# Altium schematic sheet HEATER.SchDoc(HEATER)
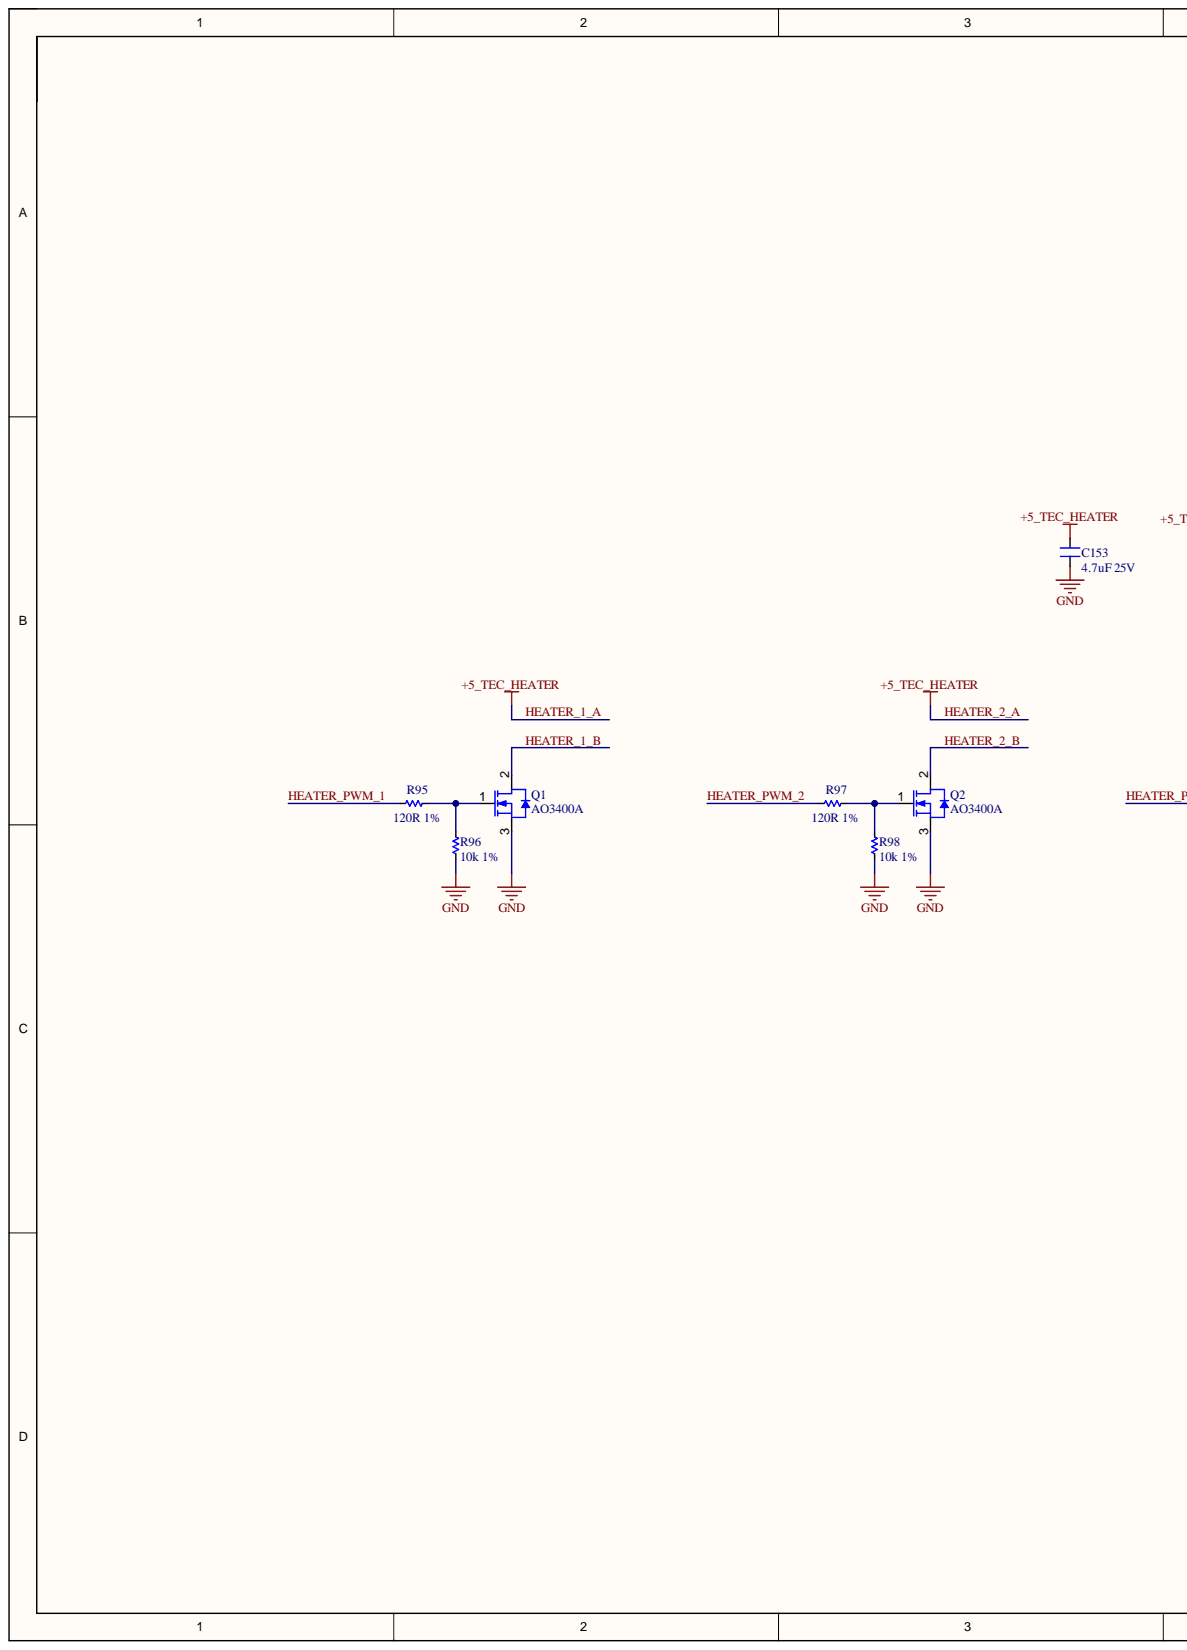
[diagram p0: HEATER.SchDoc, left]
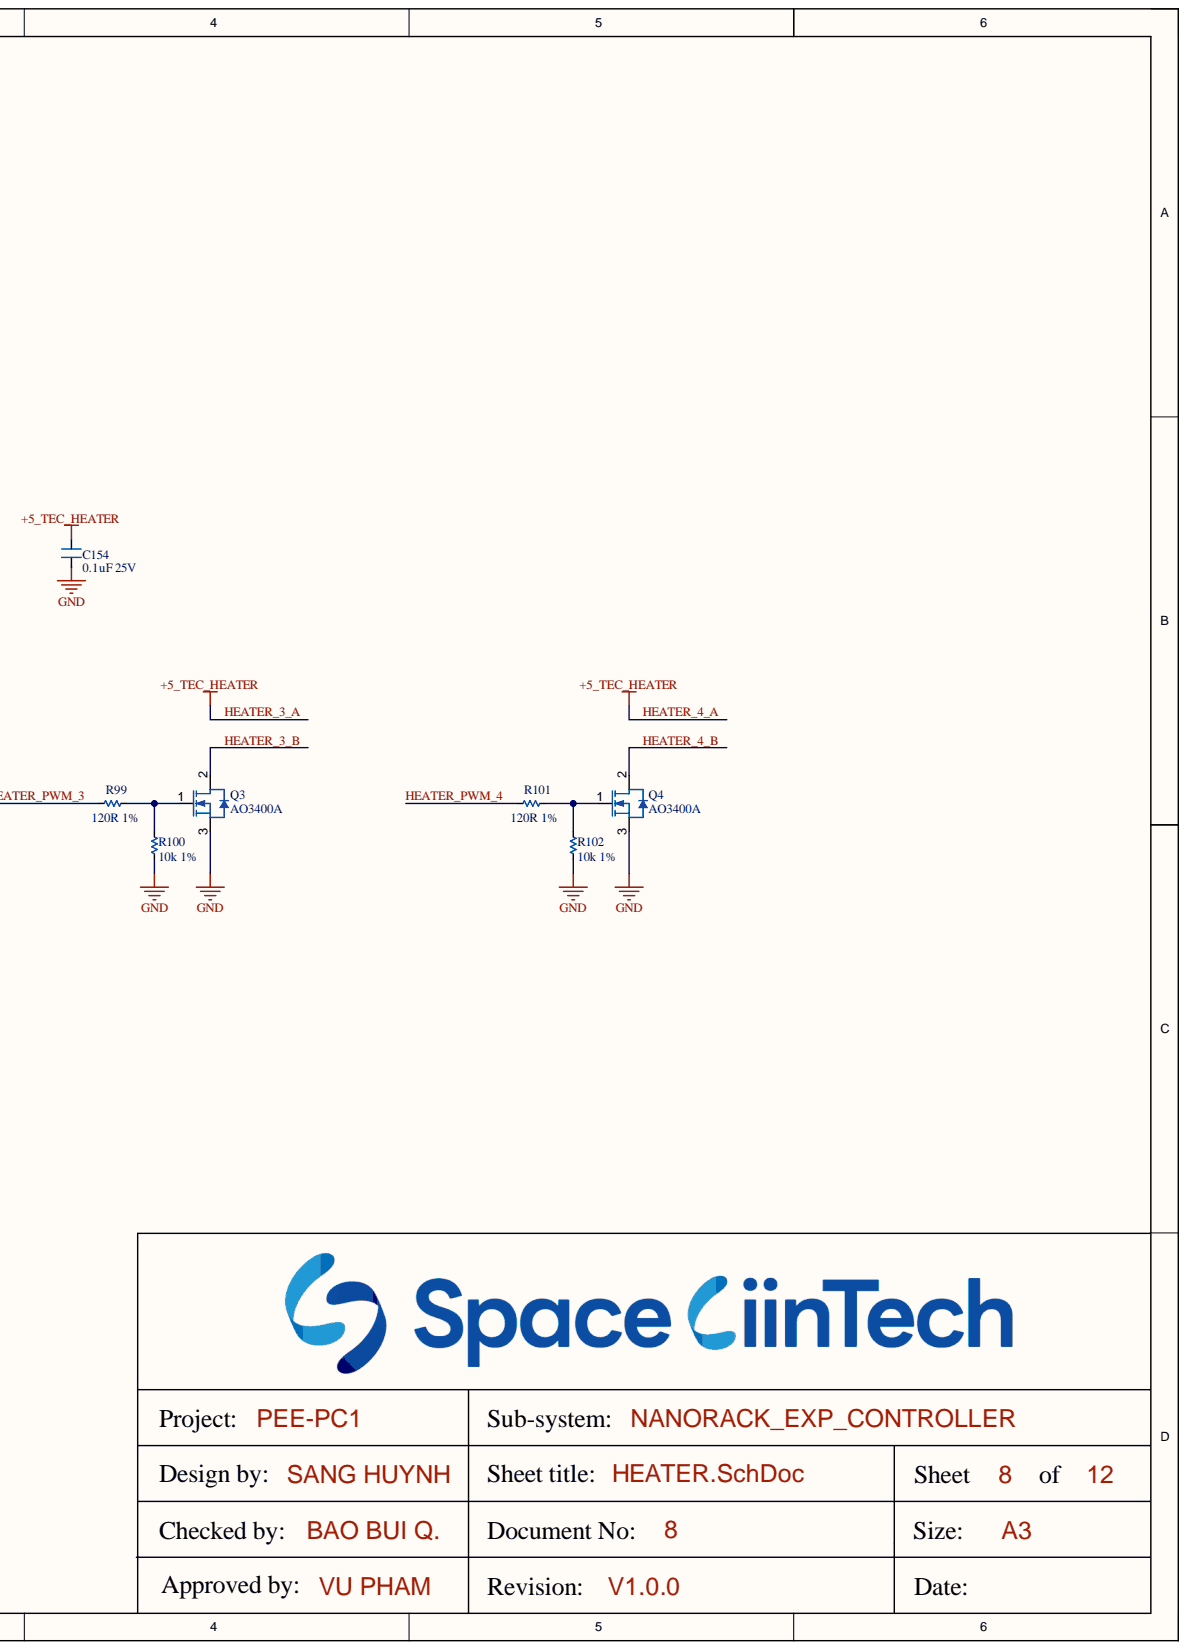
[diagram p1: HEATER.SchDoc, right]

## Parts
C153  4.7uF 25V  [CN-SMD-0603]
C154  0.1uF 25V  [CN-SMD-0603]
Q1  AO3400A  [SOT23-13_2]
Q2  AO3400A  [SOT23-13_2]
Q3  AO3400A  [SOT23-13_2]
Q4  AO3400A  [SOT23-13_2]
R95  120R 1%  [RES-SMD-0603]
R96  10k 1%  [RES-SMD-0603]
R97  120R 1%  [RES-SMD-0603]
R98  10k 1%  [RES-SMD-0603]
R99  120R 1%  [RES-SMD-0603]
R100  10k 1%  [RES-SMD-0603]
R101  120R 1%  [RES-SMD-0603]
R102  10k 1%  [RES-SMD-0603]

## Nets
GND: C153.1 C154.1 Q1.3 Q2.3 Q3.3 Q4.3 R96.1 R98.1 R100.1 R102.1
HEATER_1_A: C153.2 C154.2
HEATER_1_B: Q1.2
HEATER_2_B: Q2.2
HEATER_3_B: Q3.2
HEATER_4_B: Q4.2
HEATER_PWM_1: R95.1
HEATER_PWM_2: R97.1
HEATER_PWM_3: R99.1
HEATER_PWM_4: R101.1
NetQ1_1: Q1.1 R95.2 R96.2
NetQ2_1: Q2.1 R97.2 R98.2
NetQ3_1: Q3.1 R99.2 R100.2
NetQ4_1: Q4.1 R101.2 R102.2

## Library data of the parts
C153 C154 = Capacitors: Value: 33pF; Component Kind: Standard; Library Name: Sang_library.SchLib
Q1-Q4 = AO3400A: MOSFET N-CH 30V 5.7A SOT23-3L; Component Kind: Standard; Library Name: Sang_library.SchLib
R95-R102 = Res SMD: SMD; Manufacturer: Generic Components; Class: Passive; Component Kind: Standard; Published: 08/06/2000; Library Name: Sang_library.SchLib; Publisher: Altium Hobart Technology Centre
